# Altium schematic sheet DTU_CAT1_EG912.SchDoc(DTU_CAT1_EG912)
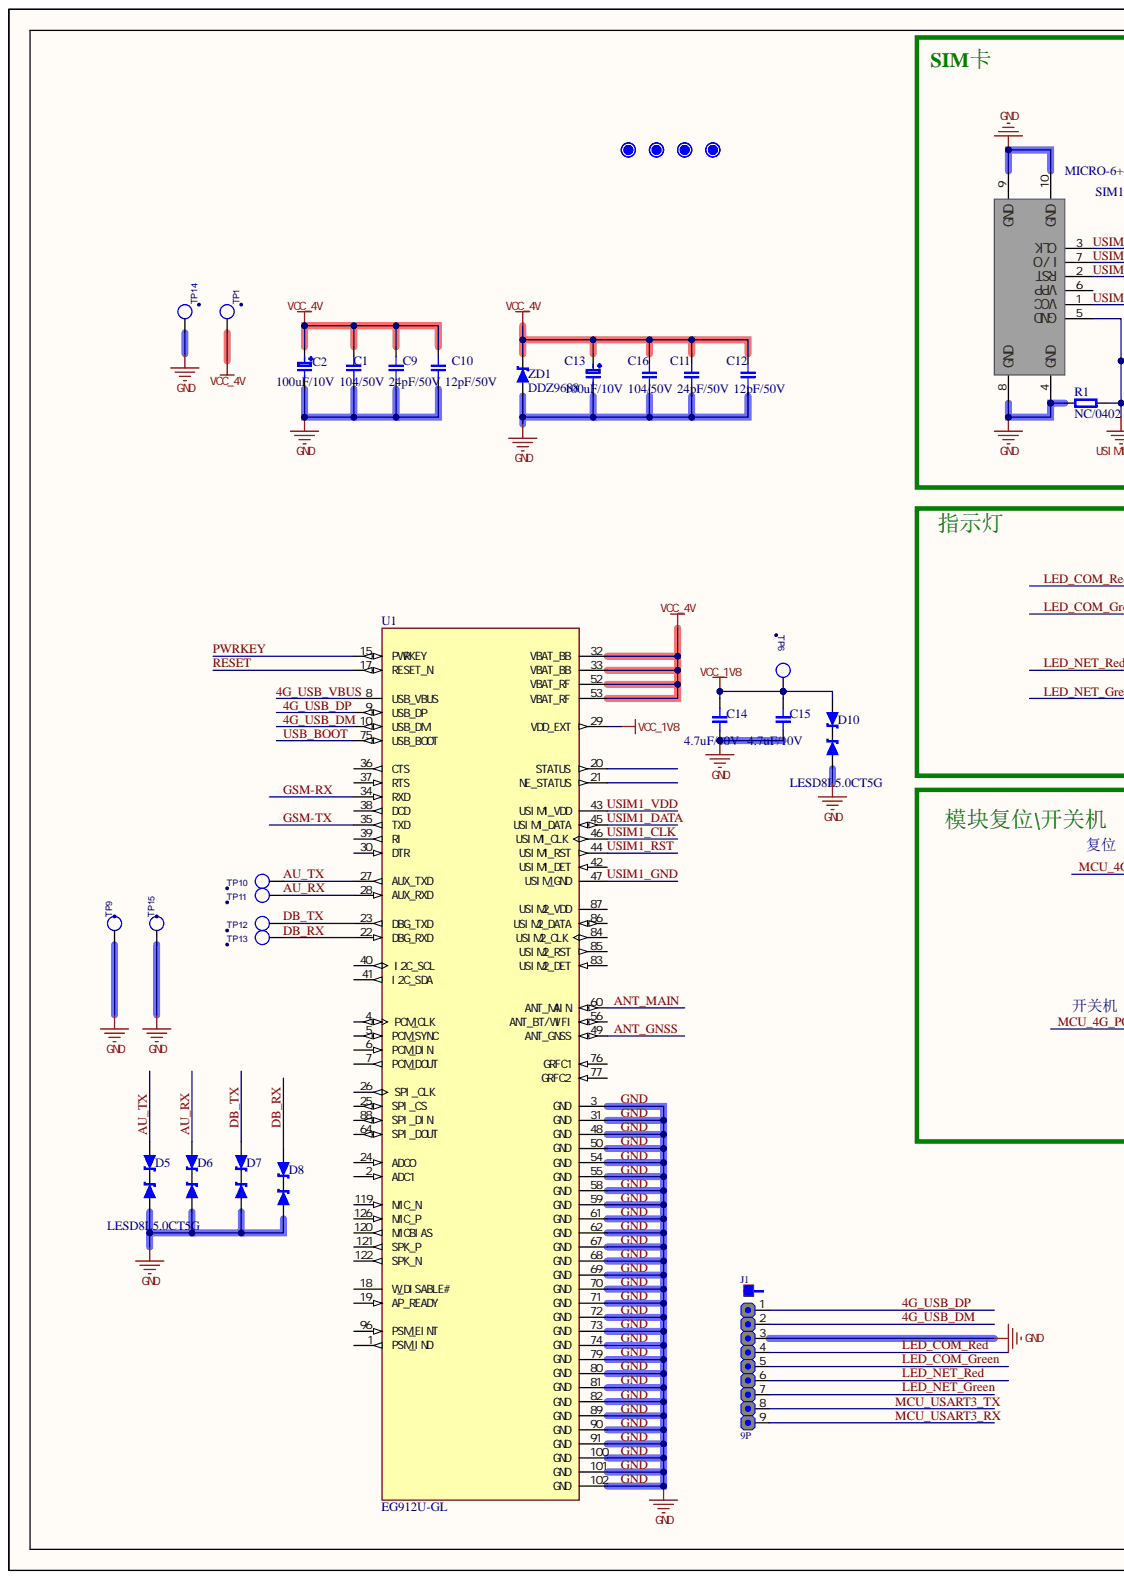
[diagram p0: DTU_CAT1_EG912.SchDoc, left]
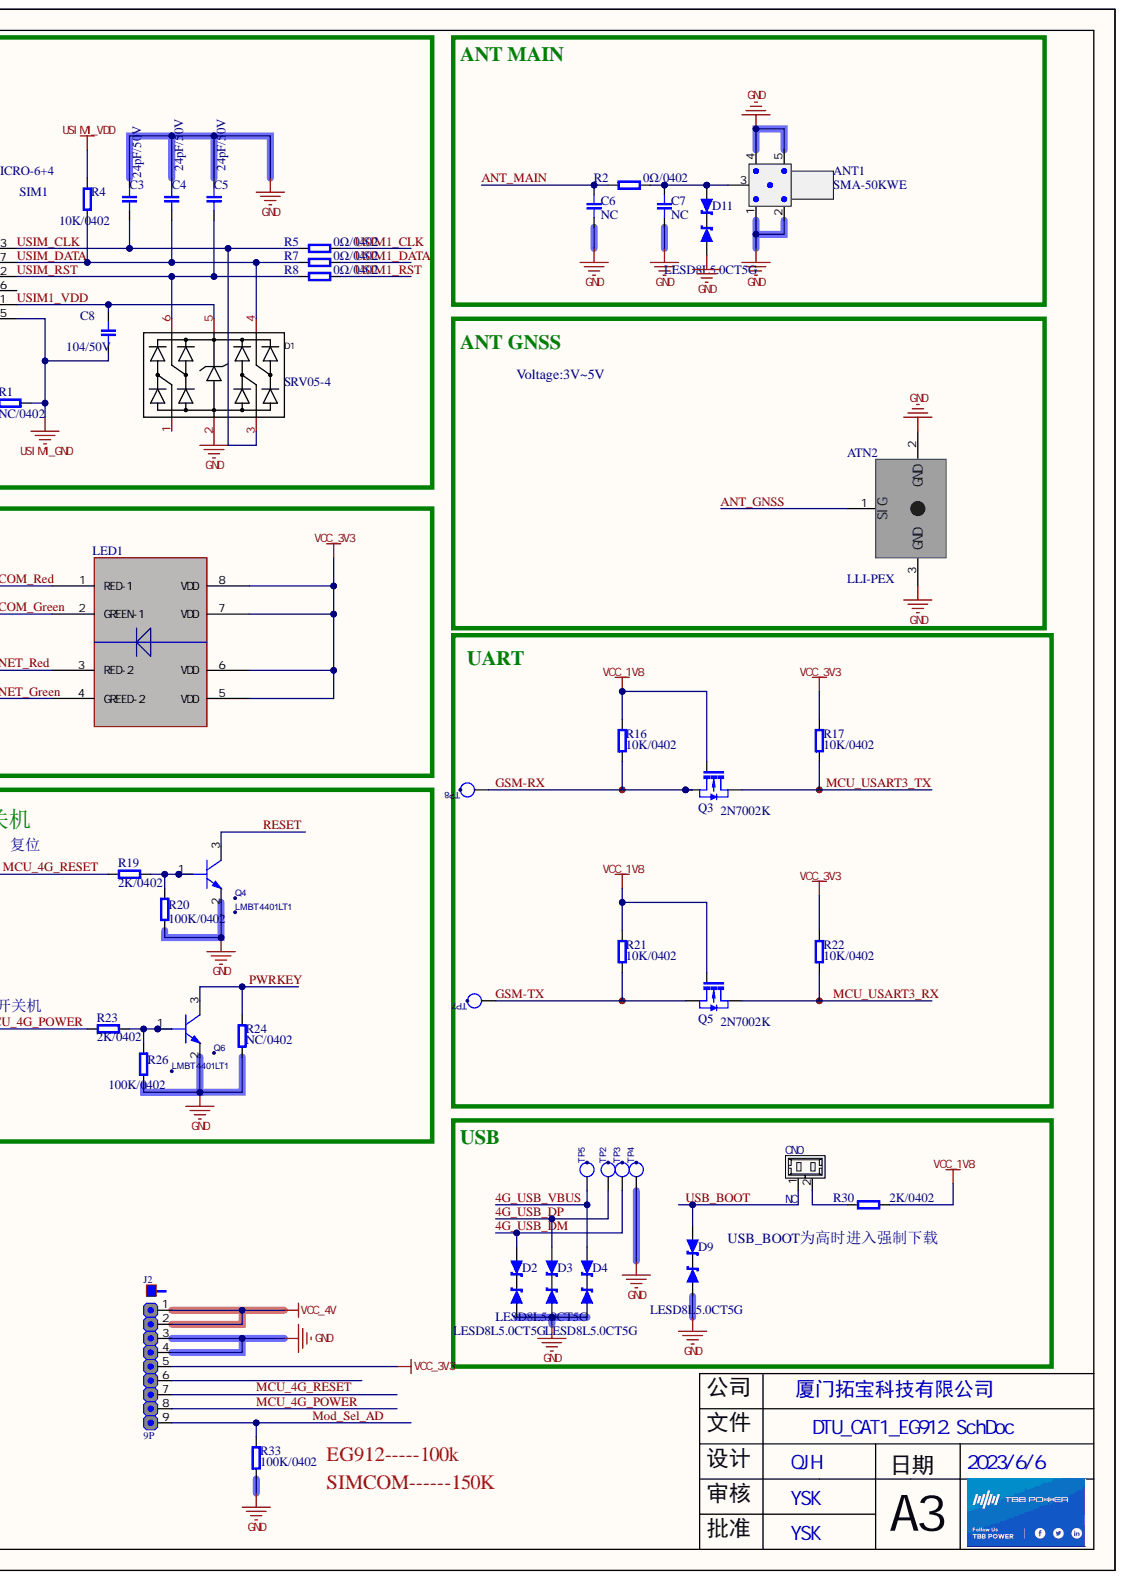
[diagram p1: DTU_CAT1_EG912.SchDoc, right]

## Parts
ANT1  SMA-50KWE  [SMA-50KWE]
ATN2  LLI-PEX  [LLI-PEX-3]
C1  104/50V  [C0402]
C2  100uF/10V  [CDT-3528]
C3  24pF/50V  [C0402]
C4  24pF/50V  [C0402]
C5  24pF/50V  [C0402]
C6  NC  [C0402]
C7  NC  [C0402]
C8  104/50V  [C0402]
C9  24pF/50V  [C0402]
C10  12pF/50V  [C0402]
C11  24pF/50V  [C0402]
C12  12pF/50V  [C0402]
C13  100uF/10V  [CDT-3528]
C14  4.7uF/10V  [C0402]
C15  4.7uF/10V  [C0402]
C16  104/50V  [C0402]
CN0  NC  [TP125-1*2P]
D1  SRV05-4  [SOT-23-6]
D2  LESD8L5.0CT5G  [SOD882]
D3  LESD8L5.0CT5G  [SOD882]
D4  LESD8L5.0CT5G  [SOD882]
D5  LESD8L5.0CT5G  [SOD882]
D6  LESD8L5.0CT5G  [SOD882]
D7  LESD8L5.0CT5G  [SOD882]
D8  LESD8L5.0CT5G  [SOD882]
D9  LESD8L5.0CT5G  [SOD882]
D10  LESD8L5.0CT5G  [SOD882]
D11  LESD8L5.0CT5G  [SOD882]
J1  9P  [CN_1_9P_254_B]
J2  9P  [CN_1_9P_254_B]
LED1  (no value)  [2LED_Bord]
MARK1  MARK1  [MARK]  (value not drawn)
MARK2  MARK1  [MARK]  (value not drawn)
MARK3  MARK1  [MARK]  (value not drawn)
MARK4  MARK1  [MARK]  (value not drawn)
Q3  2N7002K  [SOT-23-B]
Q4  LMBT4401LT1  [SOT-23]
Q5  2N7002K  [SOT-23-B]
Q6  LMBT4401LT1  [SOT-23]
R1  NC/0402  [R0402]
R2  0Ω/0402  [R0402]
R4  10K/0402  [R0402]
R5  0Ω/0402  [R0402]
R7  0Ω/0402  [R0402]
R8  0Ω/0402  [R0402]
R16  10K/0402  [R0402]
R17  10K/0402  [R0402]
R19  2K/0402  [R0402]
R20  100K/0402  [R0402]
R21  10K/0402  [R0402]
R22  10K/0402  [R0402]
R23  2K/0402  [R0402]
R24  NC/0402  [R0402]
R26  100K/0402  [R0402]
R30  2K/0402  [R0402]
R33  100K/0402  [R0402]
SIM1  MICRO-6+4  [MICRO-6+4]
TP1  T_POINT_R  [TP-SMD]  (value not drawn)
TP2  T_POINT_R  [TP-SMD]  (value not drawn)
TP3  T_POINT_R  [TP-SMD]  (value not drawn)
TP4  T_POINT_R  [TP-SMD]  (value not drawn)
TP5  T_POINT_R  [TP-SMD]  (value not drawn)
TP6  T_POINT_R  [TP-SMD]  (value not drawn)
TP7  T_POINT_R  [TP-SMD]  (value not drawn)
TP8  T_POINT_R  [TP-SMD]  (value not drawn)
TP9  T_POINT_R  [TP-SMD]  (value not drawn)
TP10  T_POINT_R  [TP-SMD]  (value not drawn)
TP11  T_POINT_R  [TP-SMD]  (value not drawn)
TP12  T_POINT_R  [TP-SMD]  (value not drawn)
TP13  T_POINT_R  [TP-SMD]  (value not drawn)
TP14  T_POINT_R  [TP-SMD]  (value not drawn)
TP15  T_POINT_R  [TP-SMD]  (value not drawn)
U1  EG912U-GL  [EG912U-XX]
ZD1  DDZ9688  [SOD-123]

## Nets
VCC_1V8: C14.1 C15.1 D10.2 Q3.1 Q5.1 R16.1 R21.1 R30.1 TP6.1 U1.29(VDD_EXT)
VCC_3V3: J2.5 LED1.5(VDD) LED1.6(VDD) LED1.7(VDD) LED1.8(VDD) R17.1 R22.1
VCC_4V: C1.2 C2.1 C9.2 C10.2 C11.2 C12.2 C13.1 C16.2 J2.1 J2.2 TP1.1 U1.32(VBAT_BB) U1.33(VBAT_BB) U1.52(VBAT_RF) U1.53(VBAT_RF) ZD1.2
4G_USB_DM: D2.2 J1.2 TP3.1 U1.10(USB_DM)
4G_USB_DP: D3.2 J1.1 TP2.1 U1.9(USB_DP)
4G_USB_VBUS: D4.2 TP5.1 U1.8(USB_VBUS)
ANT_GNSS: ATN2.1 U1.49(ANT_GNSS)
ANT_MAIN: C6.1 R2.2 U1.60(ANT_MAIN)
AU_RX: D6.2 TP11.1 U1.28(AUX_RXD)
AU_TX: D5.2 TP10.1 U1.27(AUX_TXD)
DB_RX: D8.2 TP13.1 U1.22(DBG_RXD)
DB_TX: D7.2 TP12.1 U1.23(DBG_TXD)
GND: ANT1.1 ANT1.2 ANT1.4 ANT1.5 ATN2.2 ATN2.3 C1.1 C2.2 C3.2 C4.2 C5.2 C6.2 C7.2 C9.1 C10.1 C11.1 C12.1 C13.2 C14.2 C15.2 C16.1 D1.2 D2.1 D3.1 D4.1 D5.1 D6.1 D7.1 D8.1 D9.1 D10.1 D11.1 J1.3 J2.3 J2.4 Q4.2 Q6.2 R1.1 R20.1 R24.1 R26.1 R33.2 SIM1.4(GND) SIM1.8(GND) SIM1.9(GND) SIM1.10(GND) TP4.1 TP9.1 TP14.1 TP15.1 U1.3(GND) U1.31(GND) U1.48(GND) U1.50(GND) U1.54(GND) U1.55(GND) U1.58(GND) U1.59(GND) U1.61(GND) U1.62(GND) U1.67(GND) U1.68(GND) U1.69(GND) U1.70(GND) U1.71(GND) U1.72(GND) U1.73(GND) U1.74(GND) U1.79(GND) U1.80(GND) U1.81(GND) U1.82(GND) U1.89(GND) U1.90(GND) U1.91(GND) U1.100(GND) U1.101(GND) U1.102(GND) ZD1.1
GSM-RX: Q3.2 R16.2 TP8.1 U1.34(RXD)
GSM-TX: Q5.2 R21.2 TP7.1 U1.35(TXD)
LED_COM_Green: J1.5 LED1.2(GREEN-1)
LED_COM_Red: J1.4 LED1.1(RED-1)
LED_NET_Green: J1.7 LED1.4(GREED-2)
LED_NET_Red: J1.6 LED1.3(RED-2)
MCU_4G_POWER: J2.8 R23.1
MCU_4G_RESET: J2.7 R19.1
MCU_USART3_RX: J1.9 Q5.3 R22.2
MCU_USART3_TX: J1.8 Q3.3 R17.2
Mod_Sel_AD: J2.9 R33.1
PWRKEY: Q6.3 R24.2 U1.15(PWRKEY)
RESET: Q4.3 U1.17(RESET_N)
USB_BOOT: CN0.1 D9.2 U1.75(USB_BOOT)
USIM1_CLK: R5.1 U1.46(USIM1_CLK)
USIM1_DATA: R7.1 U1.45(USIM1_DATA)
USIM1_GND: C8.1 R1.2 SIM1.5(G) U1.47(USIM_GND)
USIM1_RST: R8.1 U1.44(USIM1_RST)
USIM1_VDD: C8.2 D1.5 R4.1 SIM1.1(V) U1.43(USIM1_VDD)
USIM_CLK: C3.1 D1.3 R5.2 SIM1.3(C)
USIM_DATA: C4.1 D1.4 R4.2 R7.2 SIM1.7(I)
USIM_RST: C5.1 D1.6 R8.2 SIM1.2(R)
NetANT1_3: ANT1.3 C7.1 D11.2 R2.1
NetCN0_2: CN0.2 R30.2
NetQ4_1: Q4.1 R19.2 R20.2
NetQ6_1: Q6.1 R23.2 R26.2

Not connected: D1 1; J2 6; SIM1 6(V); U1 1(PSM_IND) 2(ADC1) 4(PCM_CLK) 5(PCM_SYNC) 6(PCM_DIN) 7(PCM_DOUT) 18(W_DISABLE#) 19(AP_READY) 20(STATUS) 21(NE_STATUS) 24(ADC0) 25(SPI_CS) 26(SPI_CLK) 30(DTR) 36(CTS) 37(RTS) 38(DCD) 39(RI) 40(I2C_SCL) 41(I2C_SDA) 42(USIM1_DET) 56(ANT_BT/WIFI) 64(SPI_DOUT) 76(GRFC1) 77(GRFC2) 83(USIM2_DET) 84(USIM2_CLK) 85(USIM2_RST) 86(USIM2_DATA) 87(USIM2_VDD) 88(SPI_DIN) 96(PSM_EINT) 119(MIC_N) 120(MICBIAS) 121(SPK_P) 122(SPK_N) 126(MIC_P)

## Library data of the parts
ANT1 = SMA-50KWE: 标准RFSMA天线座90度弯头带脚; Part_number: 10999-0033-02; Component Kind: Standard; Library Name: CONNECTOR.IntLib; 料号: 10999-0033-02
ATN2 = LLI-PEX: 贴片板端连接器 ，LLI-PEX，WIFI天线; Part_number: 20404-0041; Component Kind: Standard; Library Name: MODULE.IntLib
C1 C8 C16 = C0402_100nF_50V: 贴片电容,X7R,0402,104,100nF,50V,±10%,-55℃～125℃; Part_number: 10206-0123; Component Kind: Standard; Library Name: Capacity.SchLib
C2 C13 = CDT-100uF/10V: 贴片钽电容,TAJB107M010#,3528-21,100uF,10V,±20%,85℃,AVX; Part_number: 10206-0060; Component Kind: Standard; Library Name: CAPACITOR.IntLib; 料号: 10206-0060
C3-C5 C9 C11 = C0402_24pF_50V: 贴片电容,NPO,0402,240,24pF,50V,±5%,-55℃～125℃; Part_number: 10206-0121; Component Kind: Standard; Library Name: Capacity.SchLib
C6 C7 = C0402_NC: Component Kind: Standard; Description: NC; Part_number: NC; Library Name: Capacity.SchLib
C10 C12 = C0402_12pF_50V: 贴片电容,COG,0402,120,12pF,50V,±10%; Part_number: 10206-0085; Component Kind: Standard; Library Name: Capacity.SchLib
C14 C15 = C0402_4.7uF_10V: 贴片电容,X5R,0402,4.7uF,10V,±10%; Part_number: 10206-0112; Component Kind: Standard; Library Name: Capacity.SchLib
CN0 = A1250S-1*2P: TEST; Component Kind: Standard; Library Name: CONNECTOR.IntLib; Part_number: NC
D1 = SRV05-4: ESD,SRV05-4,SOT-23-6,击穿电压VBR 5V,钳位电压VC 10V-15V 结电容 0.2PF-0.3PF; Component Kind: Standard; Library Name: DIODE.SchLib; PCB3D: SOT-23-6
D2-D11 = LESD8L5.0CT5G: 150mw,ESD静电保护; Part_number: 10501-0039; Component Kind: Standard; Library Name: DIODE.IntLib; 料号: 10501-0039
J1 J2 = HEADER 9: 排针,2.54*4.2*1*9P,单排,L=13.2PA6C3,Odia; Part_number: 10904-0092; Component Kind: Standard; Library Name: MianBoard.SCHLIB
LED1 = Component_1: 硬件成品板,Kinergy-LED板,PCB-19204; Part_number: 82801-004-0100; Component Kind: Standard; Library Name: Schlib1.SchLib
MARK1-MARK4 = MARK1: MARK; Component Kind: Standard; Library Name: MARK.IntLib
Q3 Q5 = 2N7002K: 60V,0.3A, SMD  MOS管; Part_number: 10405-0025; Component Kind: Standard; Library Name: MOSFET.IntLib; 料号: 10405-0025
Q4 Q6 = NPN: 贴片三极管,LMBT4401LT1,2X,SOT-23,NPNSilicon,40Vdc,600mAdc; Part_number: 10404-0001; Component Kind: Standard
R1 R24 = R0402_NC: NC; Component Kind: Standard; Part_number: NC; Library Name: Resistance.SchLib
R2 R5 R7 R8 = R0402_0Ω: 贴片电阻,0402,0Ω,1/16W,±1%; Part_number: 10102-0253; Component Kind: Standard; Library Name: Resistance.SchLib
R4 R16 R17 R21 R22 = R0402_10K: 贴片电阻,RTT02103JTH,0402,10K,5%,Ralec; Part_number: 10102-0279; Component Kind: Standard; Library Name: Resistance.SchLib
R19 R23 R30 = R0402_2K: 贴片电阻,0402,2KΩ,1/16W,1%; Part_number: 10102-0309; Component Kind: Standard; Library Name: Resistance.SchLib
R20 R26 R33 = R0402_100K: 贴片电阻,0402,100KΩ,1/16W,1%; Part_number: 10102-0310; Component Kind: Standard; Library Name: Resistance.SchLib
SIM1 = MICRO-6+4: 卡座,SIM卡座,贴片MICRO,6P,PUSH,1.35H,外焊式,CSI06127135004; Part_number: 10901-0009; Component Kind: Standard; Library Name: MODULE.IntLib
U1 = EG912U-GL: Cellular EDGE, GPRS, GSM, HSPA+, LTE, UMTS Transceiver Module Antenna Not Included Surface Mount; Component Kind: Standard; Library Name: EG912YCNAA-N06-SNNSA.SchLib
ZD1 = DDZ9688: 4.7V,50uA,低电流稳压二极管; Part_number: 10502-0019; Component Kind: Standard; Library Name: ZENER DIODE.IntLib; 料号: 10502-0019
TP1-TP15 = T_POINT_R: Component Kind: Standard
